# Altium schematic sheet Car_Wiring.SchDoc
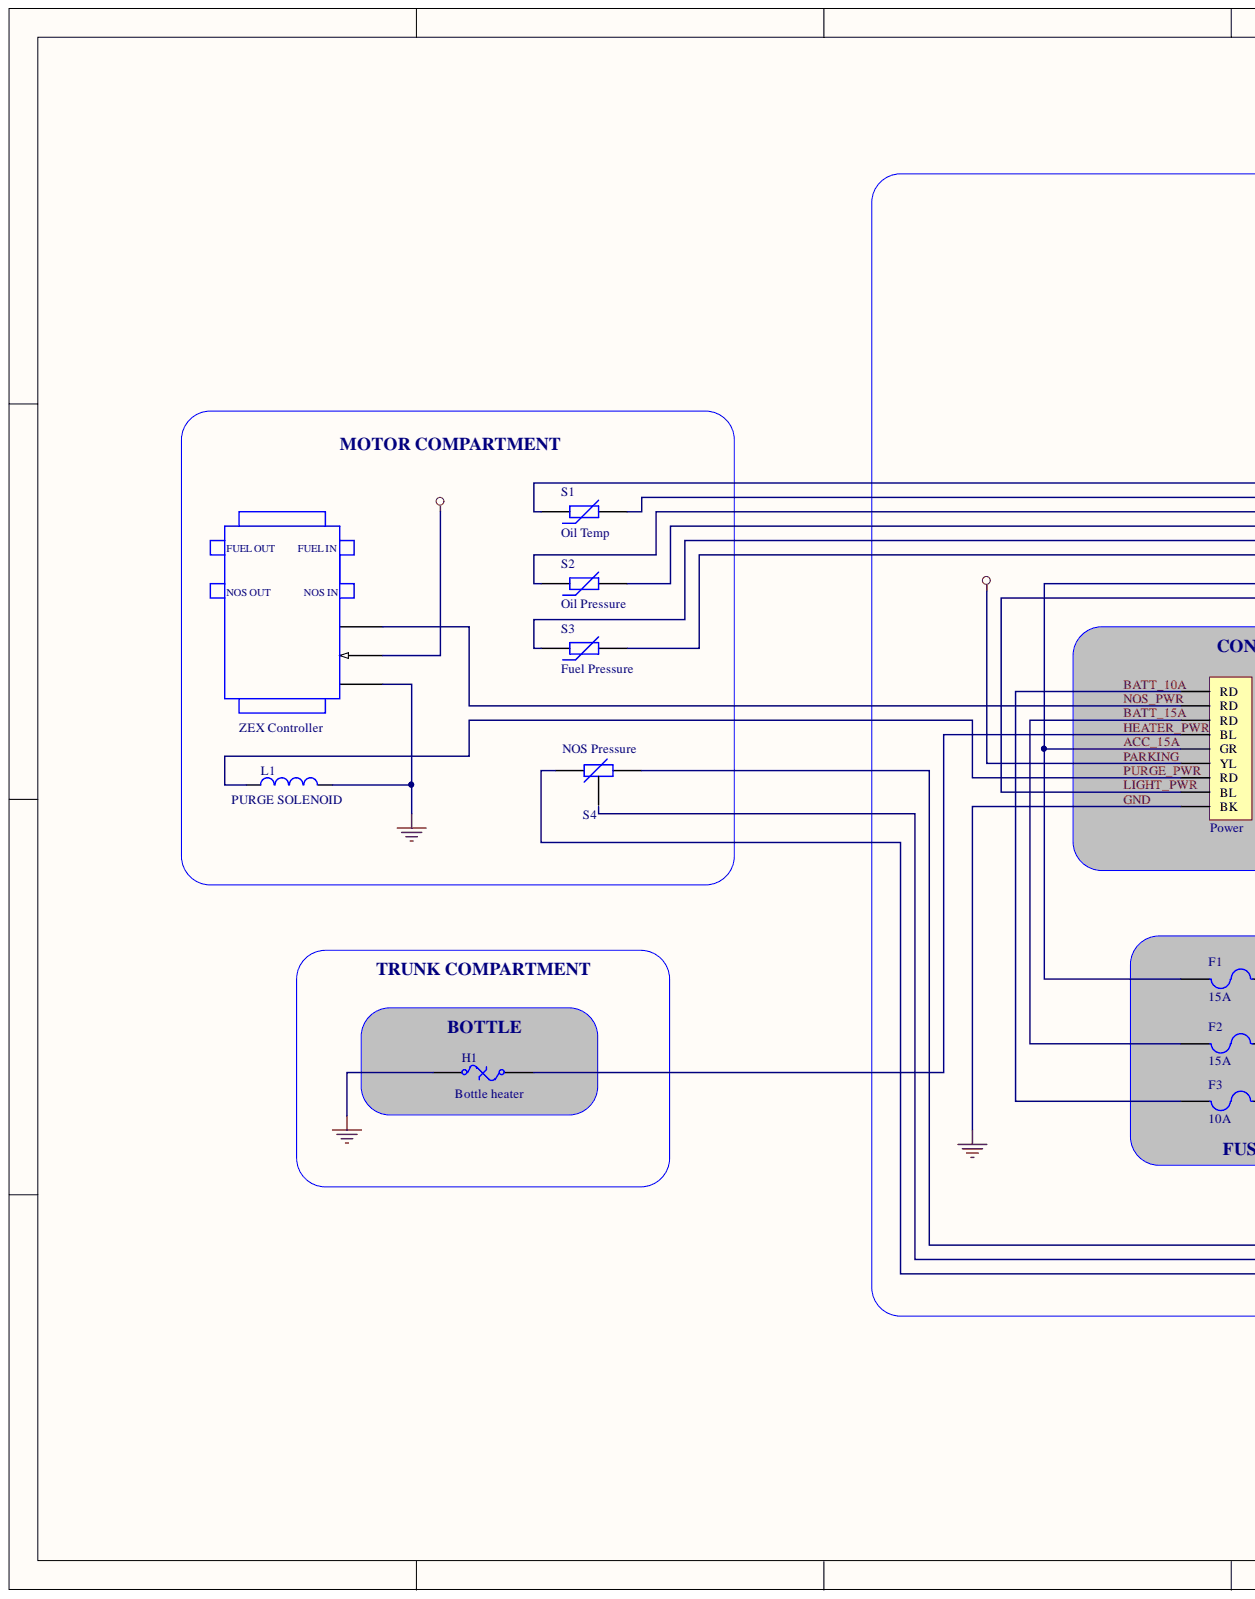
[diagram p0: Car_Wiring.SchDoc, left]
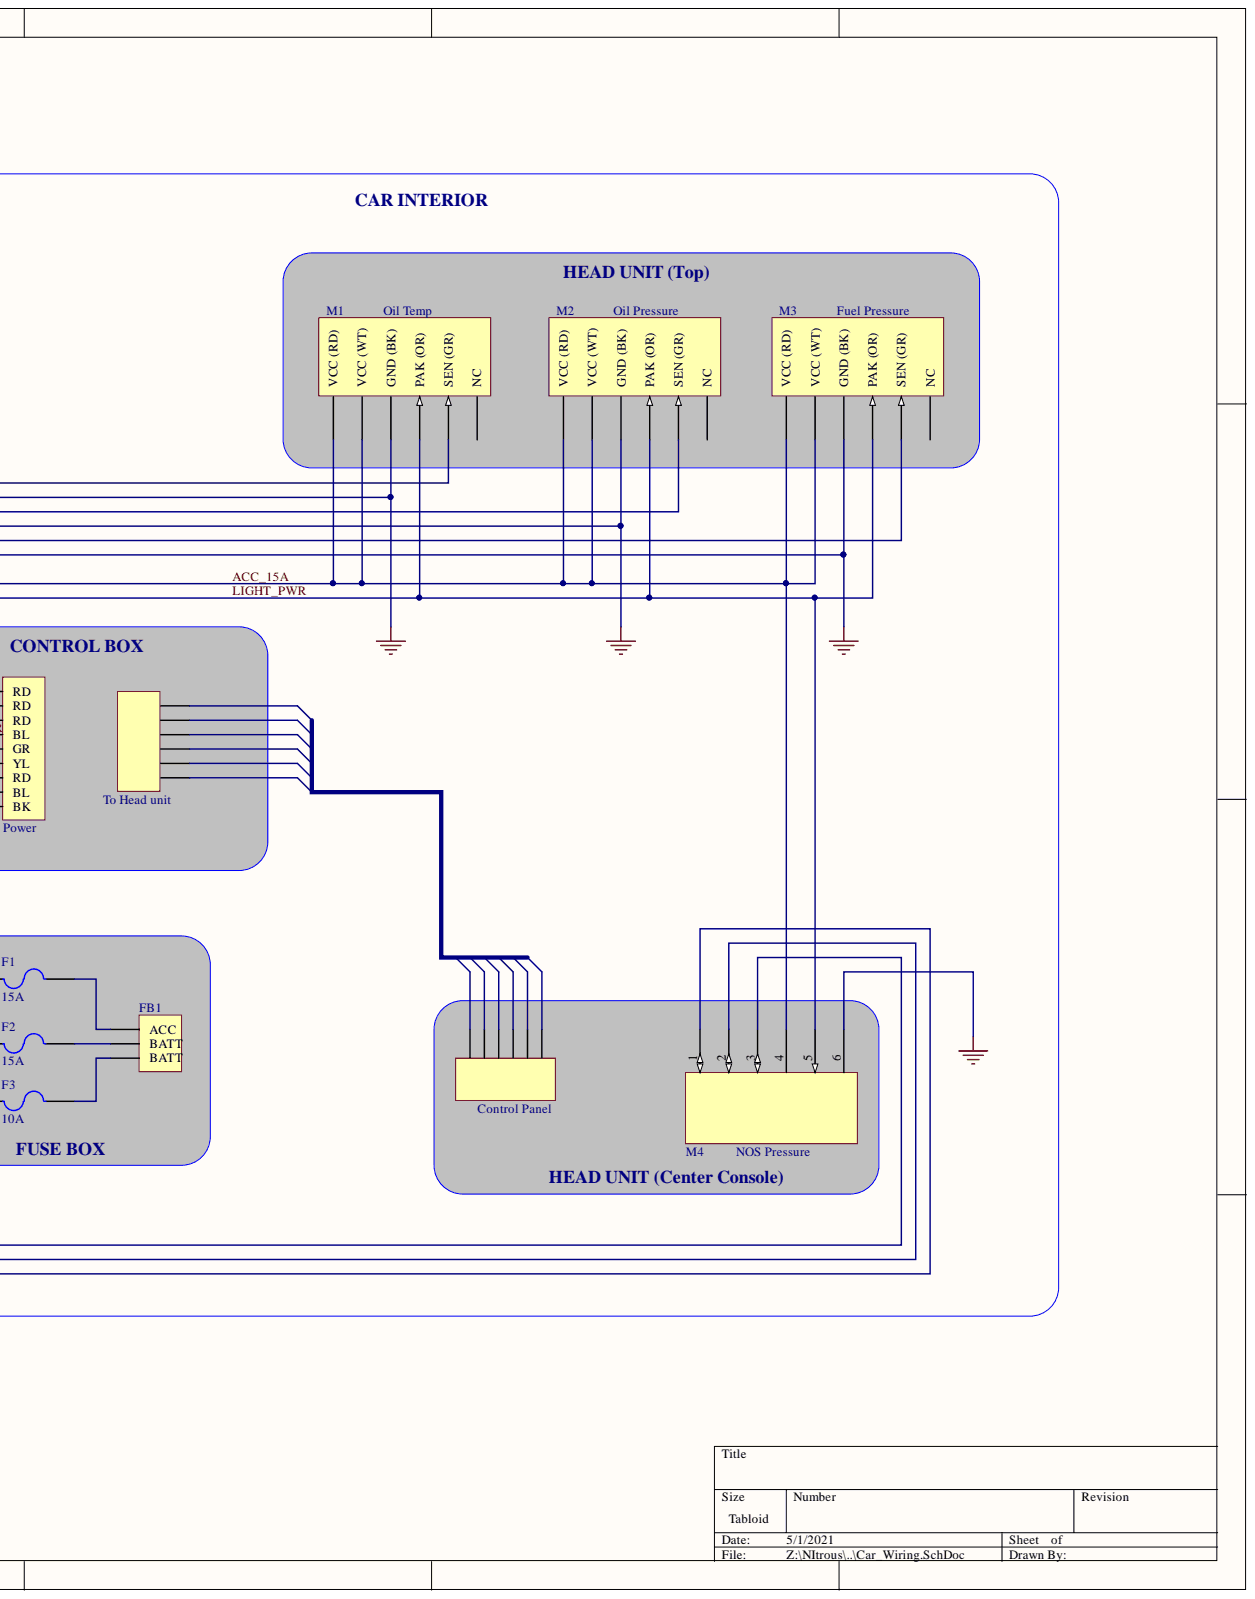
[diagram p1: Car_Wiring.SchDoc, right]

## Parts
CT1  ZEX Controller
F1  15A  [PIN-W2/E2.8]
F2  15A  [PIN-W2/E2.8]
F3  10A  [PIN-W2/E2.8]
FB1  (no value)  [MHDR1X3]
H1  Bottle heater  [PIN-W2/E2.8]
L1  PURGE SOLENOID  [0402-A]
M1  Oil Temp
M2  Oil Pressure
M3  Fuel Pressure
M4  NOS Pressure
S1  Oil Temp  [6-0805_L]
S2  Oil Pressure  [6-0805_L]
S3  Fuel Pressure  [6-0805_L]
S4  NOS Pressure
  Power  [MHDR1X9]
  To Head unit  [MOLEX_5329_6POS_RA]
  Control Panel  [MOLEX_51036_6POS]

## Nets
Net_1: .1
Net_2: .2
Net_3: .3
Net_4: .4
Net_5: .5
Net_6: .6
TPS SIGNAL: CT1.2
ACC_15A: F1.2 M1.1(VCC_(RD)) M1.2(VCC_(WT)) M2.1(VCC_(RD)) M2.2(VCC_(WT)) M3.1(VCC_(RD)) M3.2(VCC_(WT)) M4.4 .5
BATT_10A: F3.2 .1
BATT_15A: F2.2 .3
GND: CT1.1 H1.1 L1.2 M1.3(GND_(BK)) M2.3(GND_(BK)) M3.3(GND_(BK)) M4.6 S1.2 S2.2 S3.2 .9(BK)
HEATER_PWR: H1.2 .4
LIGHT_PWR: M1.4(PAK_(OR)) M2.4(PAK_(OR)) M3.4(PAK_(OR)) M4.5 .8(BL)
NOS_PWR: CT1.3 .2
PARKING: .6
PURGE_PWR: L1.1 .7(RD)
NetF1_1: F1.1 FB1.1
NetF2_1: F2.1 FB1.2
NetF3_1: F3.1 FB1.3
NetM1_5: M1.5(SEN_(GR)) S1.1
NetM2_5: M2.5(SEN_(GR)) S2.1
NetM3_5: M3.5(SEN_(GR)) S3.1
NetM4_1: M4.1 S4.2
NetM4_3: M4.3 S4.1
N00040:

Not connected: M1 6(NC); M2 6(NC); M3 6(NC); M4 2; S4 3

## Library data of the parts
CT1 = NOS_CTRL_UNIT: Component Kind: Standard; Library Name: Nitrous.SchLib
F1-F3 = Fuse 2: Fuse; Component Kind: Standard; LatestRevisionDate: 17-Jul-2002; LatestRevisionNote: Re-released for DXP Platform.; Library Name: Miscellaneous Devices.IntLib; PackageDescription: Thru-Hole; 2 Leads; PackageReference: PIN-W2/E2.8; Published: 8-Jun-2000; Simulation: FUSE; Publisher: Altium Limited
FB1 = MHDR1X3: Header, 3-Pin; Component Kind: Standard; LatestRevisionDate: 17-Jul-2002; LatestRevisionNote: Re-released for DXP Platform.; Library Name: Miscellaneous Connectors.IntLib; Publisher: Altium Limited
H1 = Fuse Thermal: Thermal Fuse; Component Kind: Standard; LatestRevisionDate: 17-Jul-2002; LatestRevisionNote: Re-released for DXP Platform.; Library Name: Miscellaneous Devices.IntLib; PackageDescription: Thru-Hole; 2 Leads; PackageReference: PIN-W2/E2.8; Published: 24-Mar-1999; Publisher: Altium Limited
L1 = Inductor: Code_IEC: 1005; Code_JEITA: 0402; Component Kind: Standard; Description: Inductor; LatestRevisionDate: 29-May-2009; LatestRevisionNote: IPC-7351 Footprint Added.; Library Name: Miscellaneous Devices.IntLib; PackageDescription: Chip Inductor; PackageReference: 0402-A; Published: 8-Jun-2000; Simulation: INDUCTOR; Publisher: Altium Limited
M1-M3 = PS_GAUGE: Component Kind: Standard; Library Name: Nitrous.SchLib
M4 = AM_GAUGE: Component Kind: Standard; Library Name: Nitrous.SchLib
S1-S3 = Res Varistor: Varistor (Voltage-Sensitive Resistor); Code_IPC: RESC2012; Component Kind: Standard; LatestRevisionDate: 29-May-2009; LatestRevisionNote: IPC-7351 Footprint Added.; Library Name: Miscellaneous Devices.IntLib; PackageDescription: Chip Resistor 0805 Case Size; PackageReference: 6-0805; PackageVersion: Mar-2003; Published: 24-Mar-1999; Publisher: Altium Limited
S4 = TEMP_SENSOR: Component Kind: Standard; Library Name: Nitrous.SchLib
 = MHDR1X9: Header, 9-Pin; Component Kind: Standard; LatestRevisionDate: 17-Jul-2002; LatestRevisionNote: Re-released for DXP Platform.; Library Name: Miscellaneous Connectors.IntLib; Publisher: Altium Limited
  = Header 6: Header, 6-Pin; Component Kind: Standard; LatestRevisionDate: 17-Jul-2002; LatestRevisionNote: Re-released for DXP Platform.; Library Name: Miscellaneous Connectors.IntLib; Publisher: Altium Limited
